# Altium schematic sheet 05_USB_JTAG.SchDoc
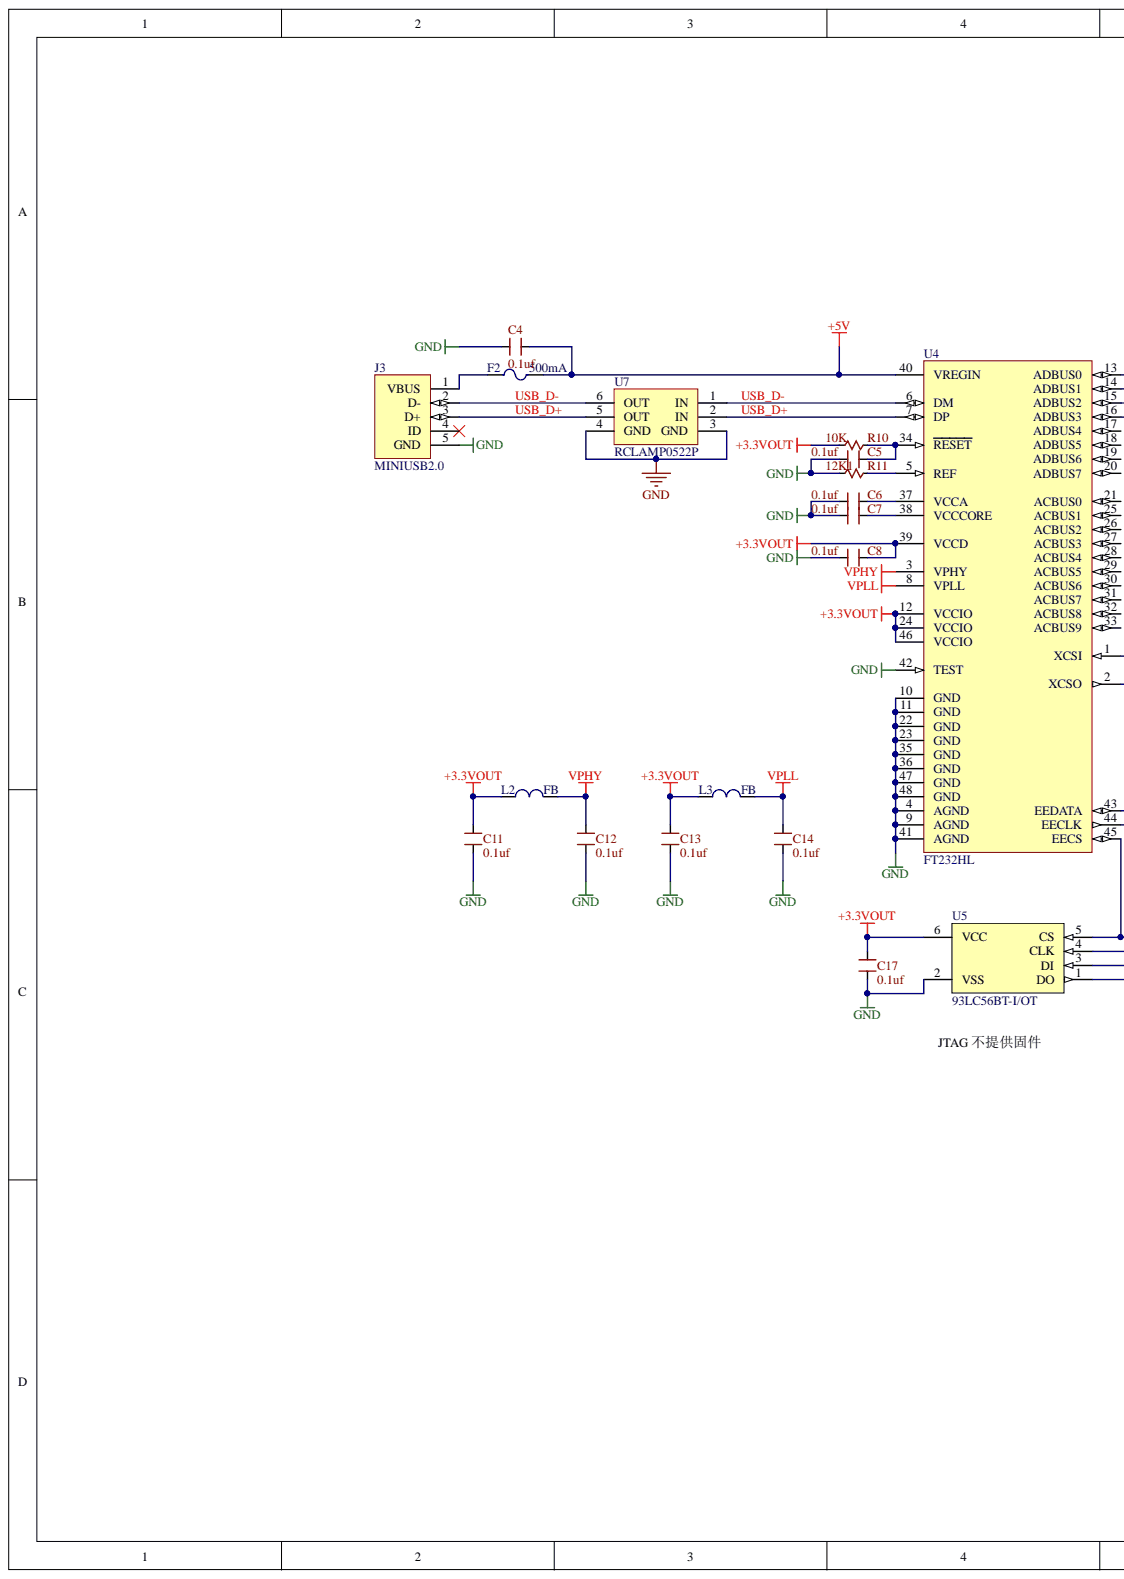
[diagram p0: 05_USB_JTAG.SchDoc, left]
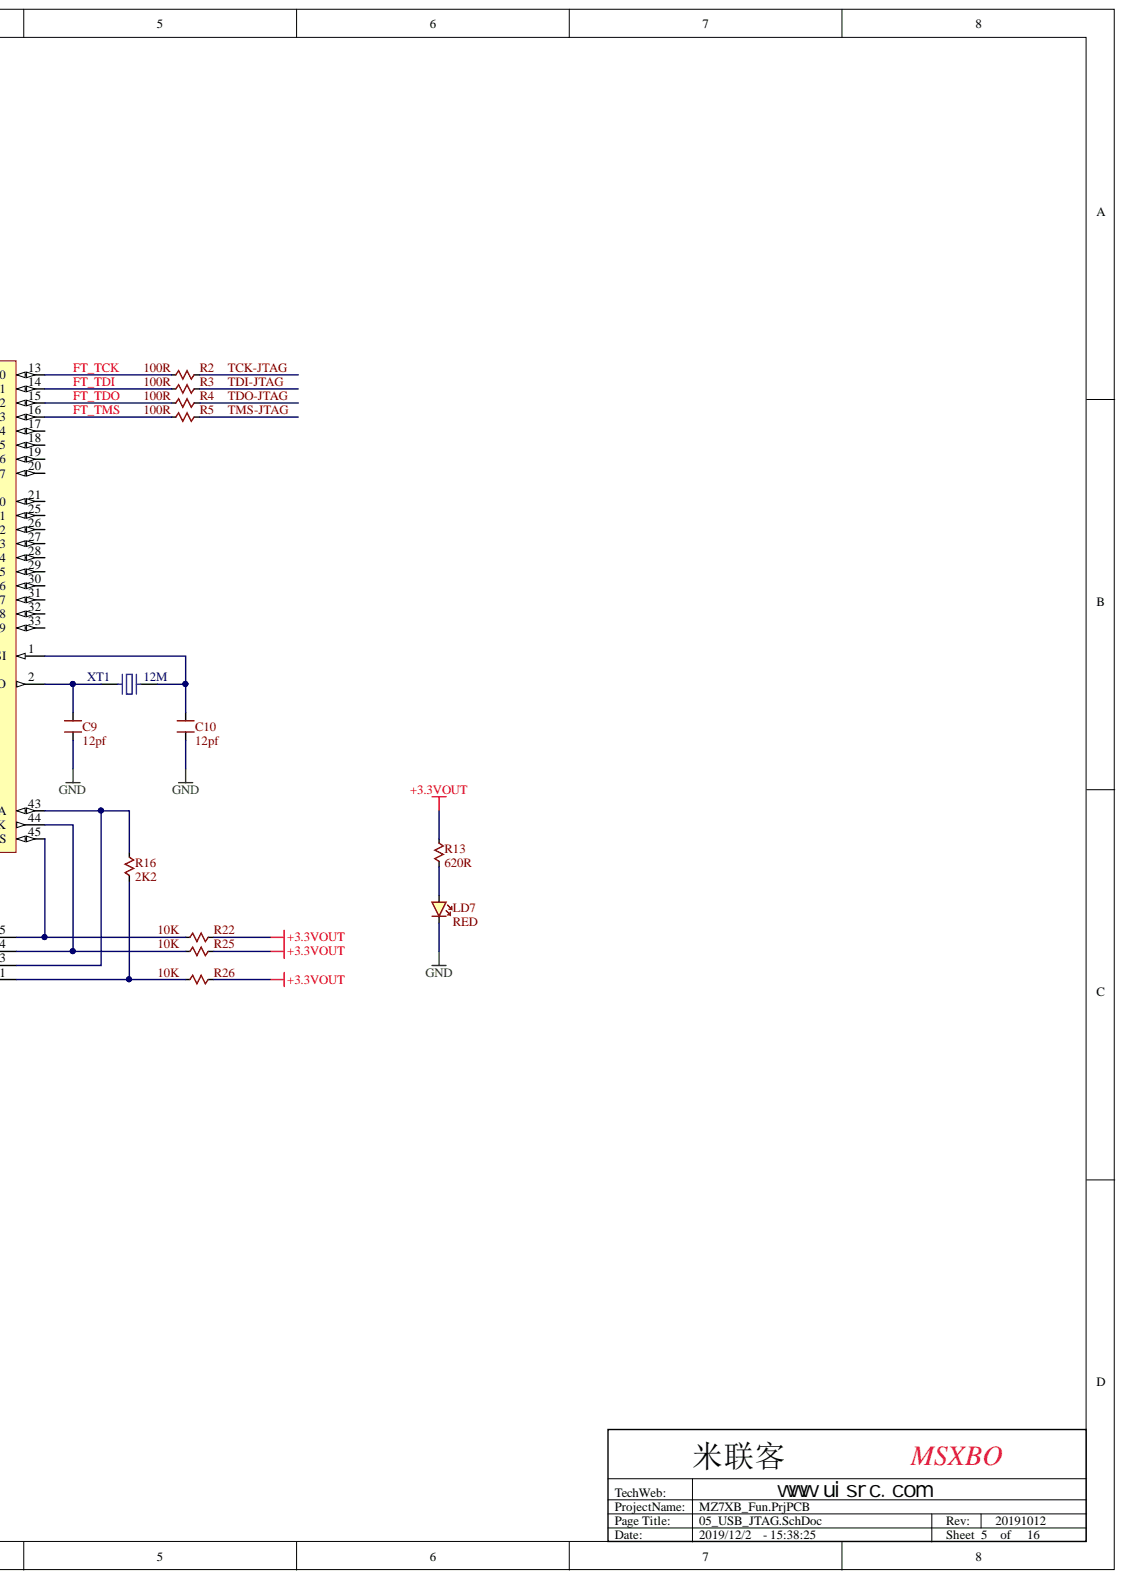
[diagram p1: 05_USB_JTAG.SchDoc, right]

## Parts
C4  0.1uf  [CAP0402]
C5  0.1uf  [CAP0402]
C6  0.1uf  [CAP0402]
C7  0.1uf  [CAP0402]
C8  0.1uf  [CAP0402]
C9  12pf  [CAP0402]
C10  12pf  [CAP0402]
C11  0.1uf  [CAP0402]
C12  0.1uf  [CAP0402]
C13  0.1uf  [CAP0402]
C14  0.1uf  [CAP0402]
C17  0.1uf  [CAP0402]
F2  500mA  [CAP0805]
J3  MINIUSB2.0  [MINI_USB2.0]
L2  value FB  [CAP0603]  (hidden comment: FB100r)
L3  value FB  [CAP0603]  (hidden comment: FB100r)
LD7  value RED  [D0603]  (hidden comment: LED)
R2  100R  [RES0402]
R3  100R  [RES0402]
R4  100R  [RES0402]
R5  100R  [RES0402]
R10  10K  [RES0402]
R11  12K1  [RES0402]
R13  620R  [RES0402]
R16  2K2  [RES0402]
R22  10K  [RES0402]
R25  10K  [RES0402]
R26  10K  [RES0402]
U4  FT232HL  [LQFP48-50P7X7X1.6]
U5  93LC56BT-I/OT  [SOT6-95P]
U7  RCLAMP0522P  [SLP1610P4_6]
XT1  12M  [3225]

## Nets
+3.3VOUT: C8.2 C11.2 C13.2 C17.2 L2.1 L3.1 R10.2 R13.1 R22.2 R25.2 R26.2 U4.12(VCCIO) U4.24(VCCIO) U4.39(VCCD) U4.46(VCCIO) U5.6(VCC)
+5V: C4.2 F2.2 U4.40(VREGIN)
GND: C4.1 C5.1 C6.1 C7.1 C8.1 C9.1 C10.1 C11.1 C12.1 C13.1 C14.1 C17.1 J3.5(GND) LD7.K R11.2 U4.4(AGND) U4.9(AGND) U4.10(GND) U4.11(GND) U4.22(GND) U4.23(GND) U4.35(GND) U4.36(GND) U4.41(AGND) U4.42(TEST) U4.47(GND) U4.48(GND) U5.2(VSS) U7.3(GND) U7.4(GND)
VPHY: C12.2 L2.2 U4.3(VPHY)
VPLL: C14.2 L3.2 U4.8(VPLL)
FT_TCK: R2.1 U4.13(ADBUS0)
FT_TDI: R3.1 U4.14(ADBUS1)
FT_TDO: R4.1 U4.15(ADBUS2)
FT_TMS: R5.1 U4.16(ADBUS3)
TCK-JTAG: R2.2
TDI-JTAG: R3.2
TDO-JTAG: R4.2
TMS-JTAG: R5.2
USB_D+: J3.3(D+) U4.7(DP) U7.2(IN) U7.5(OUT)
USB_D-: J3.2(D-) U4.6(DM) U7.1(IN) U7.6(OUT)
NetC5_2: C5.2 R10.1 U4.34(RESET)
NetC6_2: C6.2 U4.37(VCCA)
NetC7_2: C7.2 U4.38(VCCCORE)
NetC9_2: C9.2 U4.2(XCSO) XT1.1
NetC10_2: C10.2 U4.1(XCSI) XT1.3
NetF2_1: F2.1 J3.1(VBUS)
NetLD7_A: LD7.A R13.2
NetR11_1: R11.1 U4.5(REF)
NetR16_1: R16.1 U4.43(EEDATA) U5.3(DI)
NetR16_2: R16.2 R26.1 U5.1(DO)
NetR22_1: R22.1 U4.45(EECS) U5.5(CS)
NetR25_1: R25.1 U4.44(EECLK) U5.4(CLK)

Not connected: J3 4(ID); U4 17(ADBUS4) 18(ADBUS5) 19(ADBUS6) 20(ADBUS7) 21(ACBUS0) 25(ACBUS1) 26(ACBUS2) 27(ACBUS3) 28(ACBUS4) 29(ACBUS5) 30(ACBUS6) 31(ACBUS7) 32(ACBUS8) 33(ACBUS9)

## Library data of the parts
C4-C14 C17 = CAPACITOR: Generic Capacitor; Value: 10nF; Component Kind: Standard; Library Name: MIZ7035.IntLib
F2 = Fuse: Component Kind: Standard; Description: Fuse; Published: 20110225; Value: 500mA; Library Name: 胡浩.IntLib; Publisher: 胡浩
L2 L3 = Inductor1: Inductance; Component Kind: Standard; Published: 20100927; Library Name: 胡浩.IntLib; Publisher: 胡浩
LD7 = LED: Generic LED; Component Kind: Standard; Library Name: Digilent.IntLib
R2-R5 R11 R13 R16 R22 R25 R26 = RESISTOR: Generic Resistor; Component Kind: Standard; Library Name: MIZ7035.IntLib
  R2-R5: Value: 100R
  R11: Value: 12K1
  R13: Value: 620R
  R16: Value: 2K2
  R22 R25 R26: Value: 10K
R10 = RESISTOR: Generic Resistor; Value: 4.7K; Component Kind: Standard; Library Name: MIZ7035.IntLib
U4 = FT232H: USB UART; Component Kind: Standard; Published: 20141101; Library Name: 胡浩.SCHLIB; Publisher: 胡浩
U5 = 93C56A: Memory; Component Kind: Standard; Published: 20150101; Library Name: 胡浩.IntLib; Publisher: 胡浩
XT1 = CRYSTAL2: Crystal; Component Kind: Standard; Published: 20131115; Library Name: 胡浩.IntLib; Publisher: 胡浩
J3 = MINIUSB2.0: Component Kind: Standard; Library Name: 接口.IntLib; Published: 20121029; Publisher: 胡浩
U7 = RCLAMP0522P: Component Kind: Standard; Library Name: MiZ7020N_定制.SCHLIB
